# Altium schematic sheet 0_Overview.SchDoc
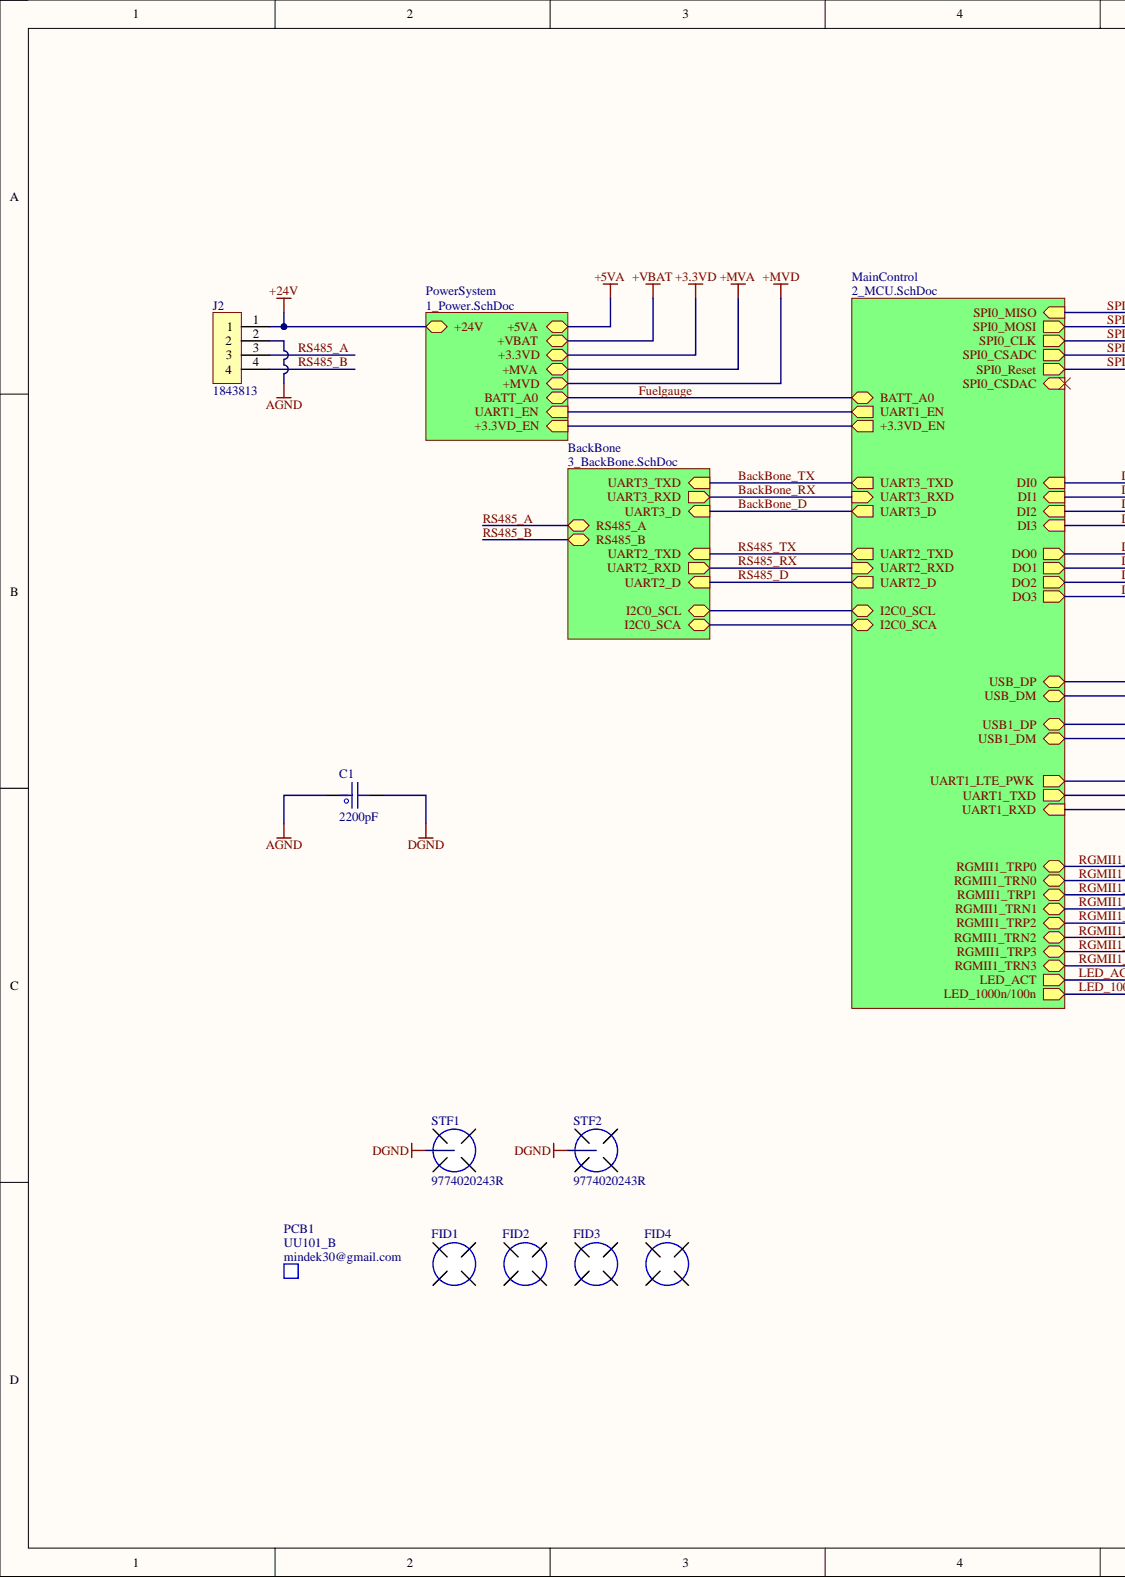
[diagram p0: 0_Overview.SchDoc, left]
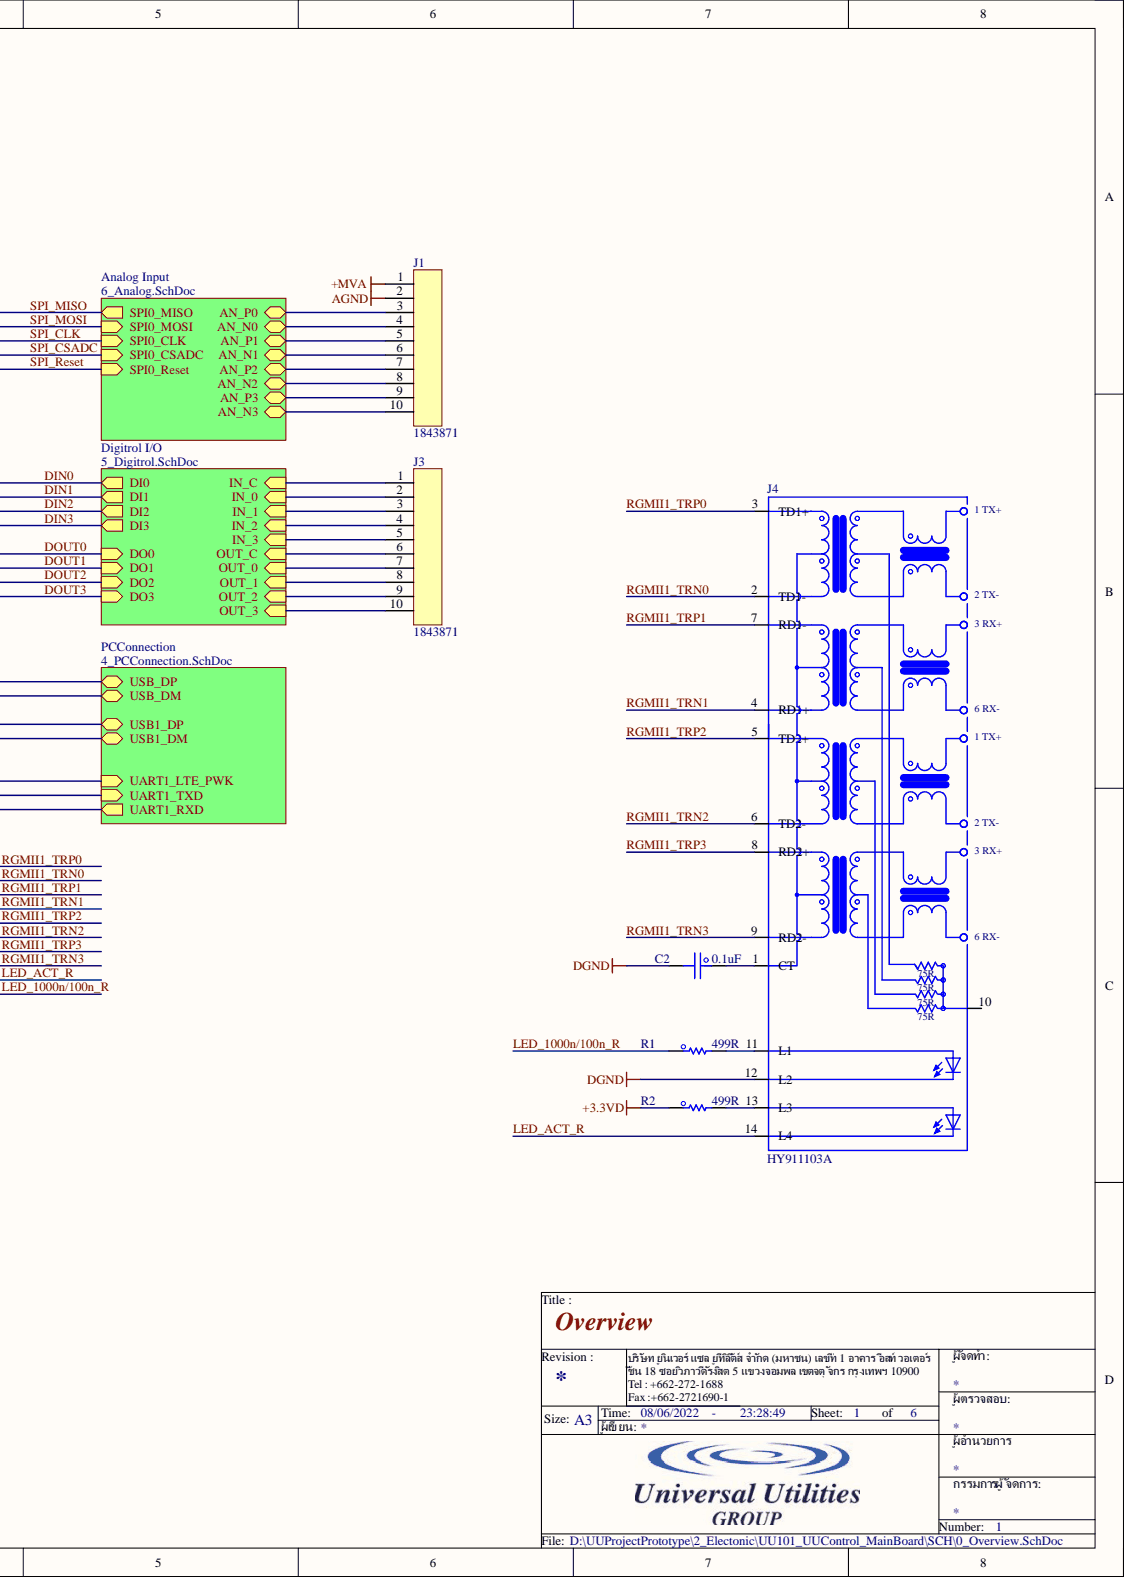
[diagram p1: 0_Overview.SchDoc, right]

## Parts
C1  2200pF  [C_1206]
C2  0.1uF  [C_0603]
FID1  FIDUCIAL  [FID]  (value not drawn)  (no BOM)
FID2  FIDUCIAL  [FID]  (value not drawn)  (no BOM)
FID3  FIDUCIAL  [FID]  (value not drawn)  (no BOM)
FID4  FIDUCIAL  [FID]  (value not drawn)  (no BOM)
J1  1843871  [CON_10P_Terminal]
J2  1843813  [CON_4P_Terminal]
J3  1843871  [CON_10P_Terminal]
J4  HY911103A  [HY911103A_HAN]
PCB1  UU101_B  Manufacturer: mindek30@gmail.com  (mechanical)
R1  499R  [R_0603]
R2  499R  [R_0603]
STF1  9774020243R  [StandOff]
STF2  9774020243R  [StandOff]

## Nets
+3.3VD: R2.1
+24V: J2.1
+MVA: J1.1
AGND: C1.1 J1.2 J2.2
DGND: C1.2 C2.2 J4.12(L2) STF1.1 STF2.1
LED_1000n/100n_R: R1.1
LED_ACT_R: J4.14(L4)
RGMII1_TRN0: J4.2(TD1-)
RGMII1_TRN1: J4.4(RD1+)
RGMII1_TRN2: J4.6(TD2-)
RGMII1_TRN3: J4.9(RD2-)
RGMII1_TRP0: J4.3(TD1+)
RGMII1_TRP1: J4.7(RD1-)
RGMII1_TRP2: J4.5(TD2+)
RGMII1_TRP3: J4.8(RD2+)
RS485_A: J2.3
RS485_B: J2.4
NetC2_1: C2.1 J4.1(CT)
NetJ1_3: J1.3
NetJ1_4: J1.4
NetJ1_5: J1.5
NetJ1_6: J1.6
NetJ1_7: J1.7
NetJ1_8: J1.8
NetJ1_9: J1.9
NetJ1_10: J1.10
NetJ3_1: J3.1
NetJ3_2: J3.2
NetJ3_3: J3.3
NetJ3_4: J3.4
NetJ3_5: J3.5
NetJ3_6: J3.6
NetJ3_7: J3.7
NetJ3_8: J3.8
NetJ3_9: J3.9
NetJ3_10: J3.10
NetJ4_10: J4.10
NetJ4_11: J4.11(L1) R1.2
NetJ4_13: J4.13(L3) R2.2
+3.3VD_EN:
+5VA:
+MVD:
+VBAT:
BackBone_D:
BackBone_RX:
BackBone_TX:
DIN0:
DIN1:
DIN2:
DIN3:
DOUT0:
DOUT1:
DOUT2:
DOUT3:
Fuelgauge:
I2C0_SCA:
I2C0_SCL:
RS485_D:
RS485_RX:
RS485_TX:
SPI0_CSDAC:
SPI_CLK:
SPI_CSADC:
SPI_MISO:
SPI_MOSI:
SPI_Reset:
UART1_EN:
UART1_LTE_PWK:
UART1_RXD:
UART1_TXD:
USB1_DM:
USB1_DP:
USB_DM:
USB_DP:

## Library data of the parts
C1 = C_Capacitor: CAP CER 2200PF 1KV X7R 1206; Manufacturer: AVX Corporation; PartNumber: 1206AC222KAT1A; Component Kind: Standard; PackageReference: 1206; PartID: 478-2953-1-ND; Value: 2200pF; Value2: 1kV; Library Name: UUSymbol.SchLib
C2 = C_Capacitor: CAP CER 0.1UF 50V X7R 0603; Manufacturer: KEMET; PartNumber: C0603C104K5RACTU; Component Kind: Standard; PackageReference: 0603; PartID: 399-5089-1-ND; Value: 0.1uF; Value2: 50V; Library Name: UUSymbol.SchLib
FID1-FID4 = FID_FIDUCIAL: Manufacturer: mindek30@gmail.com; PartNumber: UU101_B; Value: Non SE; Description: Fiducial; Library Name: UUSymbol.SchLib; PackageReference: Non SE; PartID: B; Value2: Non SE; Value3: Non SE
J1 J3 = CON_10P_Terminal: TERM BLOCK HDR 10POS 90DEG 3.5MM; Manufacturer: Phoenix Contact; Value3: 1966172; Component Kind: Standard; PartID: 277-6521-ND; PartNumber: 1843871; Value: 1843871; Library Name: UUSymbol.SchLib
J2 = CON_4P_Terminal: TERM BLOCK HDR 4POS 90DEG 3.5MM; Manufacturer: Phoenix Contact; Component Kind: Standard; PartID: 277-6088-ND; PartNumber: 1843813; Value: 1843813; Library Name: UUSymbol.SchLib
J4 = CON_RJ45_1: RJ45 RJReceptacle 1 Internal oscillator included Internal oscillator included Plugin Ethernet Connectors/Modular Connectors (RJ45 RJ11) ROHS; Manufacturer: HANRUN(Zhongshan HanRun Elec); Component Kind: Standard; PartID: C55679; PartNumber: HY911103A; Value: HY911103A; Library Name: UUSymbol.SchLib
PCB1 = PCB_Bare: BARE PCB; Library Name: UUSymbol.SchLib; PackageReference: Non SE; PartID: B; PartNumber: UU101_B; Value: UU101_B; Value3: UU101_B
R1 R2 = R_Resister: RES 499 OHM 1% 1/10W 0603; Manufacturer: Vishay Dale; PartNumber: CRCW0603499RFKEAC; Value2: 0.1W; Component Kind: Standard; PackageReference: 0603; PartID: 541-3986-1-ND; Value: 499R; Library Name: UUSymbol.SchLib
STF1 STF2 = FID_STANDOFF: ROUND STANDOFF M2X0.4 STEEL 2MM; Manufacturer: Würth Elektronik; Component Kind: Standard; PartID: 732-7073-1-ND; PartNumber: 9774020243R; Value: 9774020243R; Library Name: UUSymbol.SchLib
